# Altium schematic sheet [19]-CAMERA_PWR_CONNECTORS.SchDoc([19]-CAMERA_PWR_CONNECTORS)
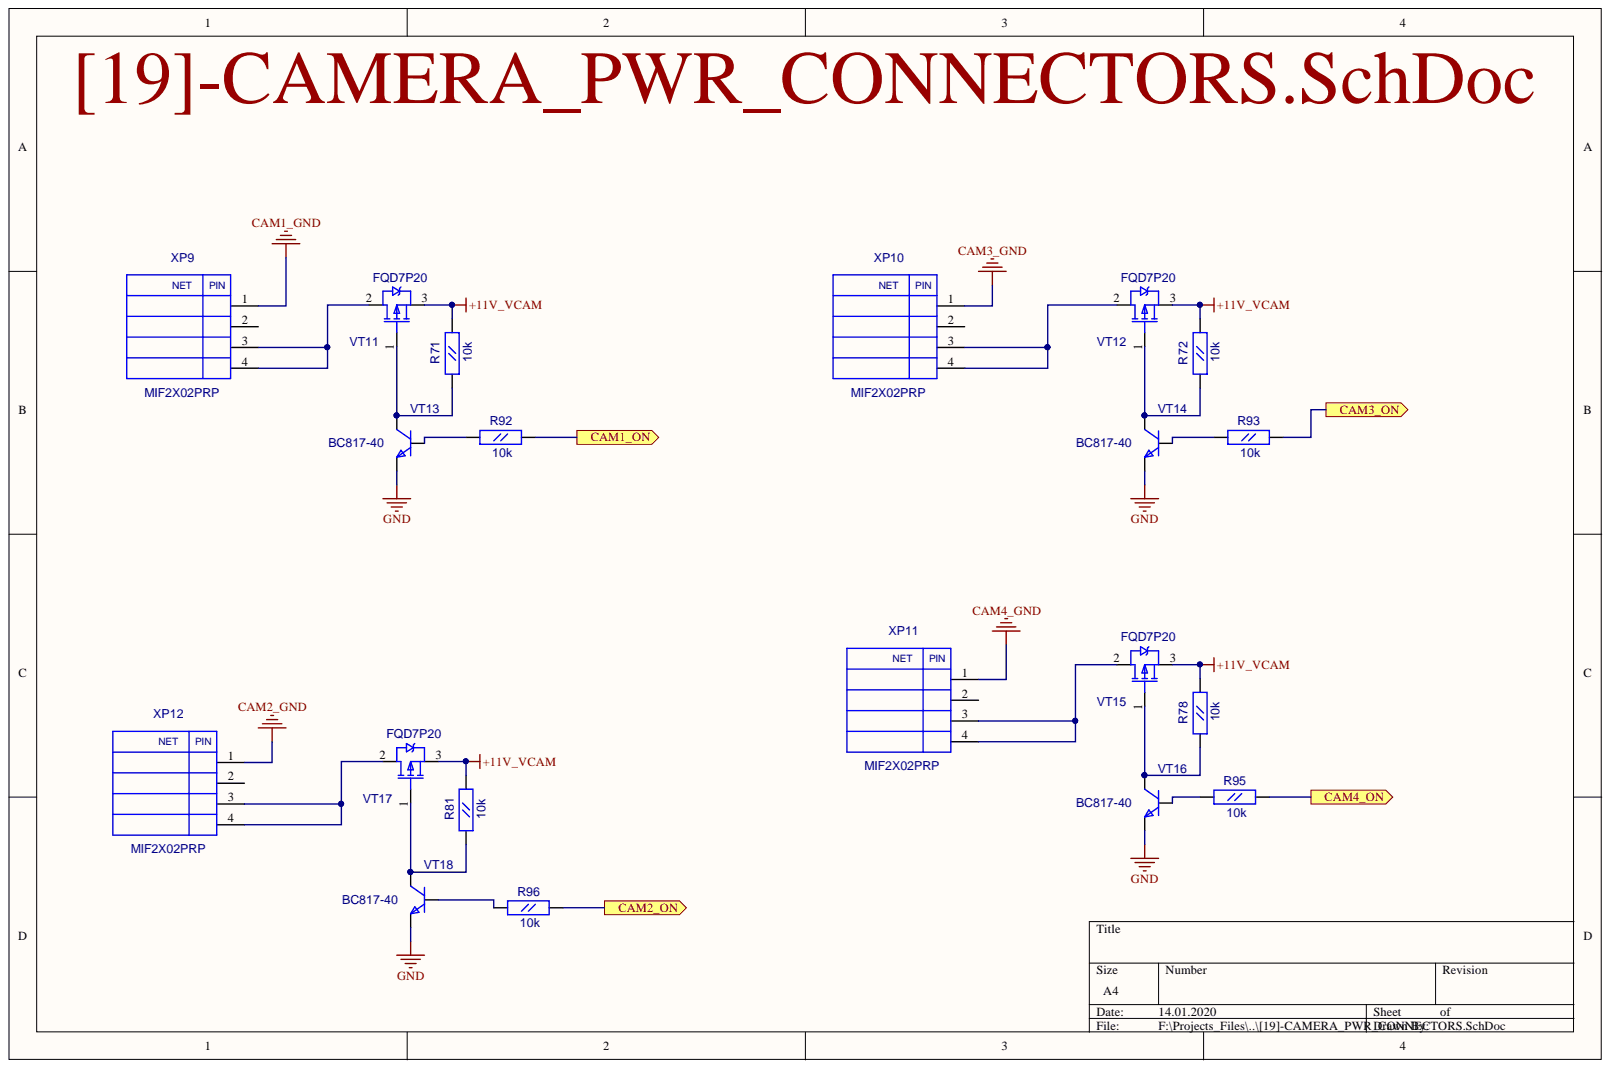
[diagram p0: [19]-CAMERA_PWR_CONNECTORS.SchDoc]

## Parts
R71  10k  [RC0603]
R72  10k  [RC0603]
R78  10k  [RC0603]
R81  10k  [RC0603]
R92  10k  [RC0603]
R93  10k  [RC0603]
R95  10k  [RC0603]
R96  10k  [RC0603]
VT11  FQD7P20  [D-PAK(TO-252AA)]
VT12  FQD7P20  [D-PAK(TO-252AA)]
VT13  BC817-40  [SOT-23(123)]
VT14  BC817-40  [SOT-23(123)]
VT15  FQD7P20  [D-PAK(TO-252AA)]
VT16  BC817-40  [SOT-23(123)]
VT17  FQD7P20  [D-PAK(TO-252AA)]
VT18  BC817-40  [SOT-23(123)]
XP9  MIF2X02PRP  [MIF2X02PRP]
XP10  MIF2X02PRP  [MIF2X02PRP]
XP11  MIF2X02PRP  [MIF2X02PRP]
XP12  MIF2X02PRP  [MIF2X02PRP]

## Ports
CAM1_ON, CAM2_ON, CAM3_ON, CAM4_ON

## Nets
+11V_VCAM: R71.2 R72.2 R78.2 R81.2 VT11.3 VT12.3 VT15.3 VT17.3
CAM1_GND: XP9.1
CAM2_GND: XP12.1
CAM3_GND: XP10.1
CAM4_GND: XP11.1
GND: VT13.2 VT14.2 VT16.2 VT18.2
CAM1_ON: R92.2 ; port CAM1_ON
CAM2_ON: R96.2 ; port CAM2_ON
CAM3_ON: R93.2 ; port CAM3_ON
CAM4_ON: R95.2 ; port CAM4_ON
NetR71_1: R71.1 VT11.1 VT13.3
NetR72_1: R72.1 VT12.1 VT14.3
NetR78_1: R78.1 VT15.1 VT16.3
NetR81_1: R81.1 VT17.1 VT18.3
NetR92_1: R92.1 VT13.1
NetR93_1: R93.1 VT14.1
NetR95_1: R95.1 VT16.1
NetR96_1: R96.1 VT18.1
NetVT11_2: VT11.2 XP9.3 XP9.4
NetVT12_2: VT12.2 XP10.3 XP10.4
NetVT15_2: VT15.2 XP11.3 XP11.4
NetVT17_2: VT17.2 XP12.3 XP12.4

Not connected: XP9 2; XP10 2; XP11 2; XP12 2

## Library data of the parts
R71 R72 R78 R81 R92 R93 R95 R96 = RC0603: Value: 10k; Description: RC0603; Component Kind: Standard; Library Name: fizik_lib.SchLib
VT11 VT12 VT15 VT17 = IRFR9220: HEXFET Power MOSFET; OriginalName: IRLML5103; ComponentField7: Published 8-Jun-2000; Value: FQD7P20; Component Kind: Standard; Library Name: gprs_modem_2.SchLib
VT13 VT14 VT16 VT18 = BC846BLT1: NPN 500ma transistor; ComponentField7: Published 29-Sep-2000; Value: BC817-40; Simulation: BC846BLT1; OriginalName: BC846BLT1; Component Kind: Standard; Library Name: fizik_lib.SchLib
XP9-XP12 = MIF2X02PRP: Description: MIF2X02PRP; Component Kind: Standard; Library Name: fizik_lib.SchLib
